# Altium schematic sheet GENERADORA V1.5.SchDoc
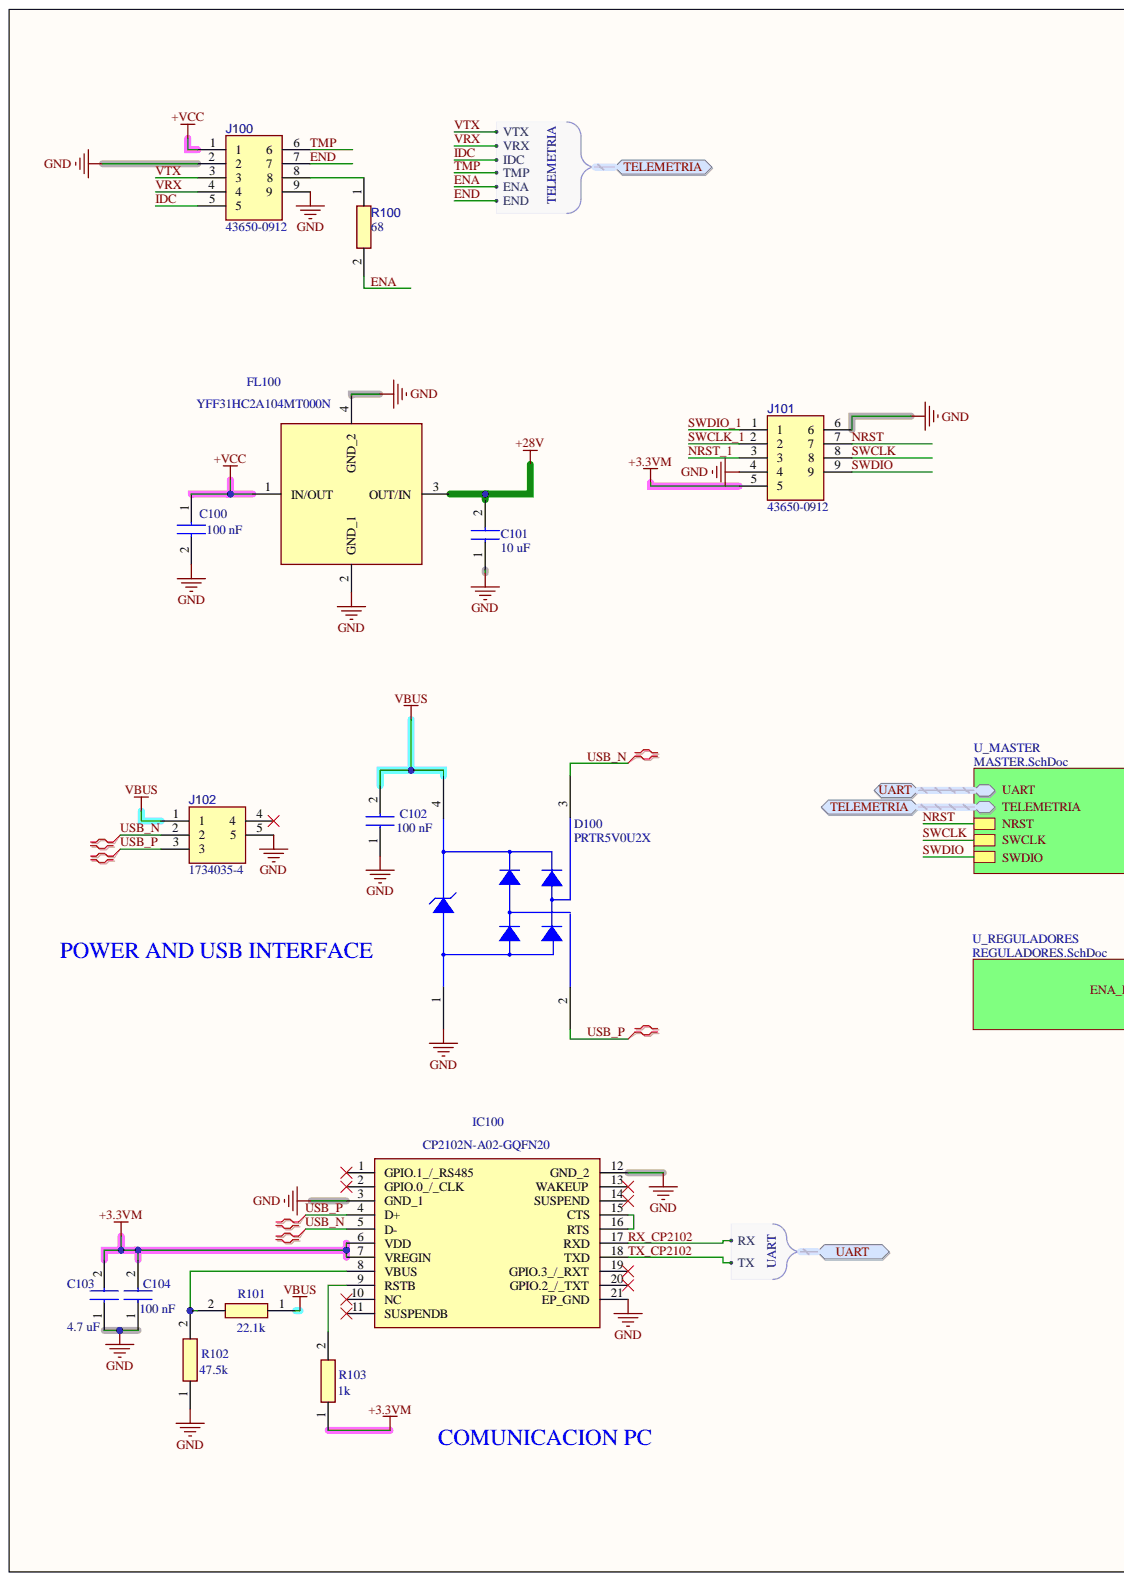
[diagram p0: GENERADORA V1.5.SchDoc, left]
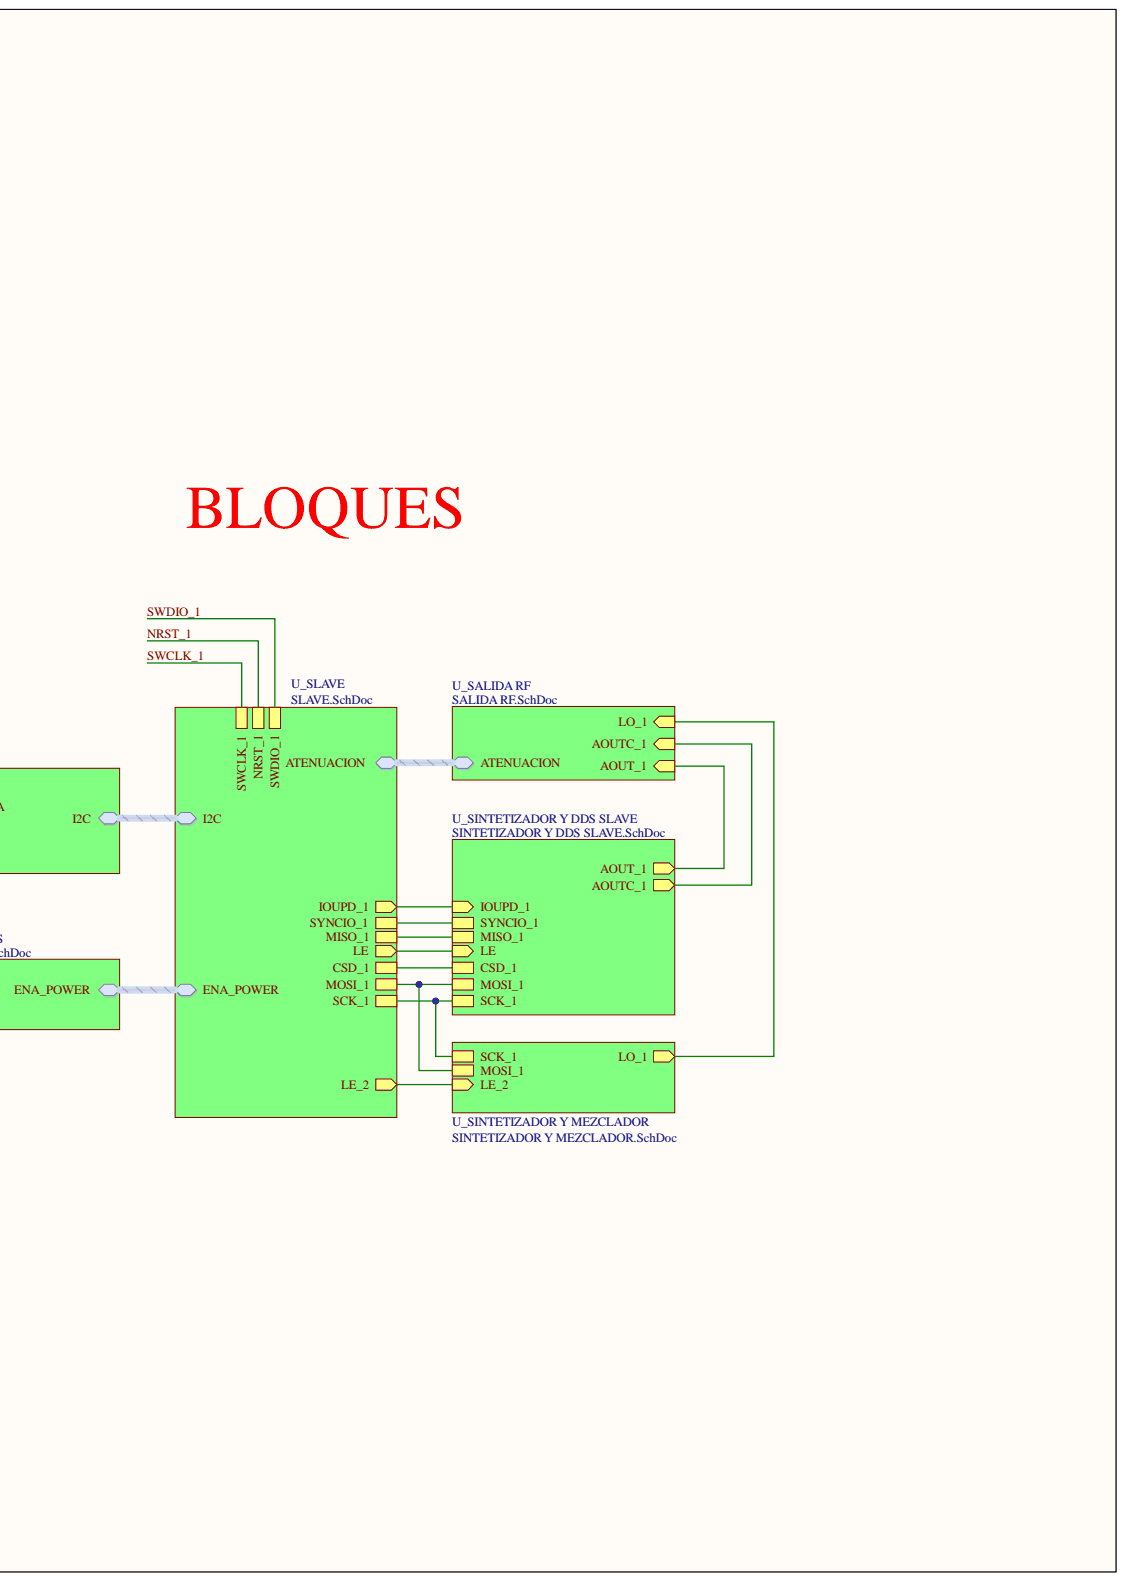
[diagram p1: GENERADORA V1.5.SchDoc, right]

## Parts
C100  100 nF  [CAPC3216X94N]
C101  10 uF  [CAPC3216X94N]
C102  100 nF  [CAPC3216X94N]
C103  4.7 uF  [C0603]
C104  100 nF  [C0603]
D100  PRTR5V0U2X  [AP4-00001]
FL100  YFF31HC2A104MT000N  [YFF31HC2A104MT000N]
IC100  CP2102N-A02-GQFN20  [CP2102NA02GQFN20]
J100  43650-0912  [43650-09(12,13,14)]
J101  43650-0912  [43650-09(12,13,14)]
J102  1734035-4  [1734035-2USB]
R100  68  [RESC1608X55N]
R101  22.1k  [RESC1608X55N]
R102  47.5k  [RESC1608X55N]
R103  1k  [RESC1608X55N]

## Ports
TELEMETRIA, TELEMETRIA.ENA, TELEMETRIA.END, TELEMETRIA.IDC, TELEMETRIA.TMP, TELEMETRIA.VRX, TELEMETRIA.VTX, UART, UART.ENA, UART.END, UART.IDC, UART.RX, UART.TMP, UART.TX, UART.VRX, UART.VTX

## Nets
+3.3VM: C103.2 C104.2 IC100.6(VDD) IC100.7(VREGIN) J101.5 R103.1
+28V: C101.2 FL100.3(OUT/IN)
+VCC: C100.1 FL100.1(IN/OUT) J100.1
GND: C100.2 C101.1 C102.1 C103.1 C104.1 D100.1 FL100.2(GND_1) FL100.4(GND_2) IC100.3(GND_1) IC100.12(GND_2) IC100.21(EP_GND) J100.2 J100.9 J101.4 J101.6 J102.5 R102.1
VBUS: C102.2 D100.4 J102.1 R101.1
ENA: R100.2 ; port TELEMETRIA
END: J100.7 ; port TELEMETRIA
IDC: J100.5 ; port TELEMETRIA
NRST: J101.7
NRST_1: J101.3
RX_CP2102: IC100.17(RXD) ; port UART
SWCLK: J101.8
SWCLK_1: J101.2
SWDIO: J101.9
SWDIO_1: J101.1
TMP: J100.6 ; port TELEMETRIA
TX_CP2102: IC100.18(TXD) ; port UART
USB_N: D100.3 IC100.5(D-) J102.2
USB_P: D100.2 IC100.4(D+) J102.3
VRX: J100.4 ; port TELEMETRIA
VTX: J100.3 ; port TELEMETRIA
NetIC100_1: IC100.1(GPIO.1_/_RS485)
NetIC100_2: IC100.2(GPIO.0_/_CLK)
NetIC100_8: IC100.8(VBUS) R101.2 R102.2
NetIC100_9: IC100.9(RSTB) R103.2
NetIC100_10: IC100.10(NC)
NetIC100_11: IC100.11(SUSPENDB)
NetIC100_13: IC100.13(WAKEUP)
NetIC100_14: IC100.14(SUSPEND)
NetIC100_15: IC100.15(CTS) IC100.16(RTS)
NetIC100_19: IC100.19(GPIO.3_/_RXT)
NetIC100_20: IC100.20(GPIO.2_/_TXT)
NetJ100_8: J100.8 R100.1
NetJ102_4: J102.4
AOUT_1:
AOUTC_1:
ATENUACION.AT1:
ATENUACION.AT2:
ATENUACION.AT4:
ATENUACION.AT8:
ATENUACION.AT16:
CSD_1:
ENA_POWER.EN1.8_1:
ENA_POWER.EN3.3_1:
ENA_POWER.EN5.0_1:
I2C.SCL:
I2C.SDA:
IOUPD_1:
LE:
LE_2:
LO_1:
MISO_1:
MOSI_1:
SCK_1:
SYNCIO_1:
UART.ENA: ; port UART
UART.END: ; port UART
UART.IDC: ; port UART
UART.TMP: ; port UART
UART.VRX: ; port UART
UART.VTX: ; port UART

## Library data of the parts
C100-C102 = KAM31BR81H103KT: Capacitor; Manufacturer_Name: KYOCERA; Component Kind: Standard; Datasheet Link: https://datasheets.kyocera-avx.com/AutoMLCCKAM.pdf; Height: 0.94mm; Library Name: LIBRERIA_GEN_V2.0.SCHLIB; Manufacturer_Part_Number: KAM31BR81H103KT; Mouser Part Number: 581-KAM31BR81H103KT; Mouser Price/Stock: https://www.mouser.co.uk/ProductDetail/KYOCERA-AVX/KAM31BR81H103KT?qs=Jm2GQyTW%2FbjzijSUJGW%2FJA%3D%3D
C103 C104 = C0603H470J5GACTU: Capacitor; Manufacturer_Name: KEMET; Component Kind: Standard; Datasheet Link: https://content.kemet.com/datasheets/KEM_C1001_C0G_200C_SMD.pdf; Height: 0.87mm; Library Name: LIBRERIA_GEN_V2.0.SCHLIB; Manufacturer_Part_Number: C0603H470J5GACTU
D100 = PRTR5V0U2X: Double rail-to-rail USB ESD protection diode; Manufacturer: Philips; OrcadPartType: Integrated Circuits&Special function&Protection; AN: A06-0236; TemperatureRange: -40 to +85 C; Component Kind: Standard; Height: 1,10000002384186; MPN: PRTR5V0U2X; PartType: Buy; Value: PRTR5V0U2X; Library Name: SAM4S_Xplained_Pro.IntLib
FL100 = YFF31HC2A104MT000N: Filter; Manufacturer_Name: TDK; Arrow Part Number: YFF31HC2A104MT000N; Arrow Price/Stock: https://www.arrow.com/en/products/yff31hc2a104mt000n/tdk?region=nac; Component Kind: Standard; Datasheet Link: https://product.tdk.com/system/files/dam/doc/product/emc/emc/3tf/catalog/3tf_commercial_power_yff-hc_en.pdf; Height: 1.5mm; Library Name: LIBRERIA_GEN_V2.0.SCHLIB; Manufacturer_Part_Number: YFF31HC2A104MT000N; Mouser Part Number: 810-YFF31HC2A104MTHN; Mouser Price/Stock: https://www.mouser.co.uk/ProductDetail/TDK/YFF31HC2A104MT000N?qs=1gGcJ6gR7Y2xnDLNcVCkdg%3D%3D
IC100 = CP2102N-A02-GQFN20: Integrated Circuit; Manufacturer_Name: Silicon Labs; Arrow Part Number: CP2102N-A02-GQFN20; Arrow Price/Stock: https://www.arrow.com/en/products/cp2102n-a02-gqfn20/silicon-labs?region=europe; Component Kind: Standard; Datasheet Link: https://www.silabs.com/documents/public/data-sheets/cp2102n-datasheet.pdf; Height: 0.8mm; Library Name: LIBRERIA_GEN_V2.0.SCHLIB; Manufacturer_Part_Number: CP2102N-A02-GQFN20; Mouser Part Number: 634-CP2102NA02GQFN20; Mouser Price/Stock: https://www.mouser.co.uk/ProductDetail/Silicon-Labs/CP2102N-A02-GQFN20?qs=u16ybLDytRaG8WdlP0fT2g%3D%3D
J100 J101 = 43650-0912: Connector; Manufacturer_Name: Molex; Component Kind: Standard; Datasheet Link: https://www.molex.com/pdm_docs/sd/436500212_sd.pdf; Height: 5.57mm; Manufacturer_Part_Number: 43650-0912; Mouser Part Number: 538-43650-0912; Mouser Price/Stock: https://www.mouser.co.uk/ProductDetail/Molex/43650-0912?qs=lm8WgJiVcEuC1RHlaQB4sg%3D%3D
J102 = 1734035-4: Connector; Manufacturer_Name: TE Connectivity; Arrow Part Number: 1734035-4; Arrow Price/Stock: https://www.arrow.com/en/products/1734035-4/te-connectivity?region=nac; Component Kind: Standard; Datasheet Link: http://www.te.com/commerce/DocumentDelivery/DDEController?Action=srchrtrv&DocNm=1734035&DocType=Customer+Drawing&DocLang=English&DocFormat=pdf&PartCntxt=1734035-4; Library Name: SamacSys.SchLib; Manufacturer_Part_Number: 1734035-4; Mouser Part Number: 571-1734035-4; Mouser Price/Stock: https://www.mouser.co.uk/ProductDetail/TE-Connectivity/1734035-4?qs=ZYpP4JSRRNCyjylIh5thIQ%3D%3D
R100-R103 = CR0603-FX-1431ELF: Resistor; Manufacturer_Name: Bourns; Component Kind: Standard; Datasheet Link: https://www.bourns.com/pdfs/chpreztr.pdf; Height: 0.55mm; Manufacturer_Part_Number: CR0603-FX-1431ELF; Mouser Part Number: 652-CR0603FX-1431ELF; Mouser Price/Stock: https://www.mouser.co.uk/ProductDetail/Bourns/CR0603-FX-1431ELF?qs=e7cxVwPieurCzASkAzK2%252Bg%3D%3D
  R101-R103: Library Name: LIBRERIA_GEN_V2.0.SCHLIB
